# Altium schematic sheet load-cell.SchDoc
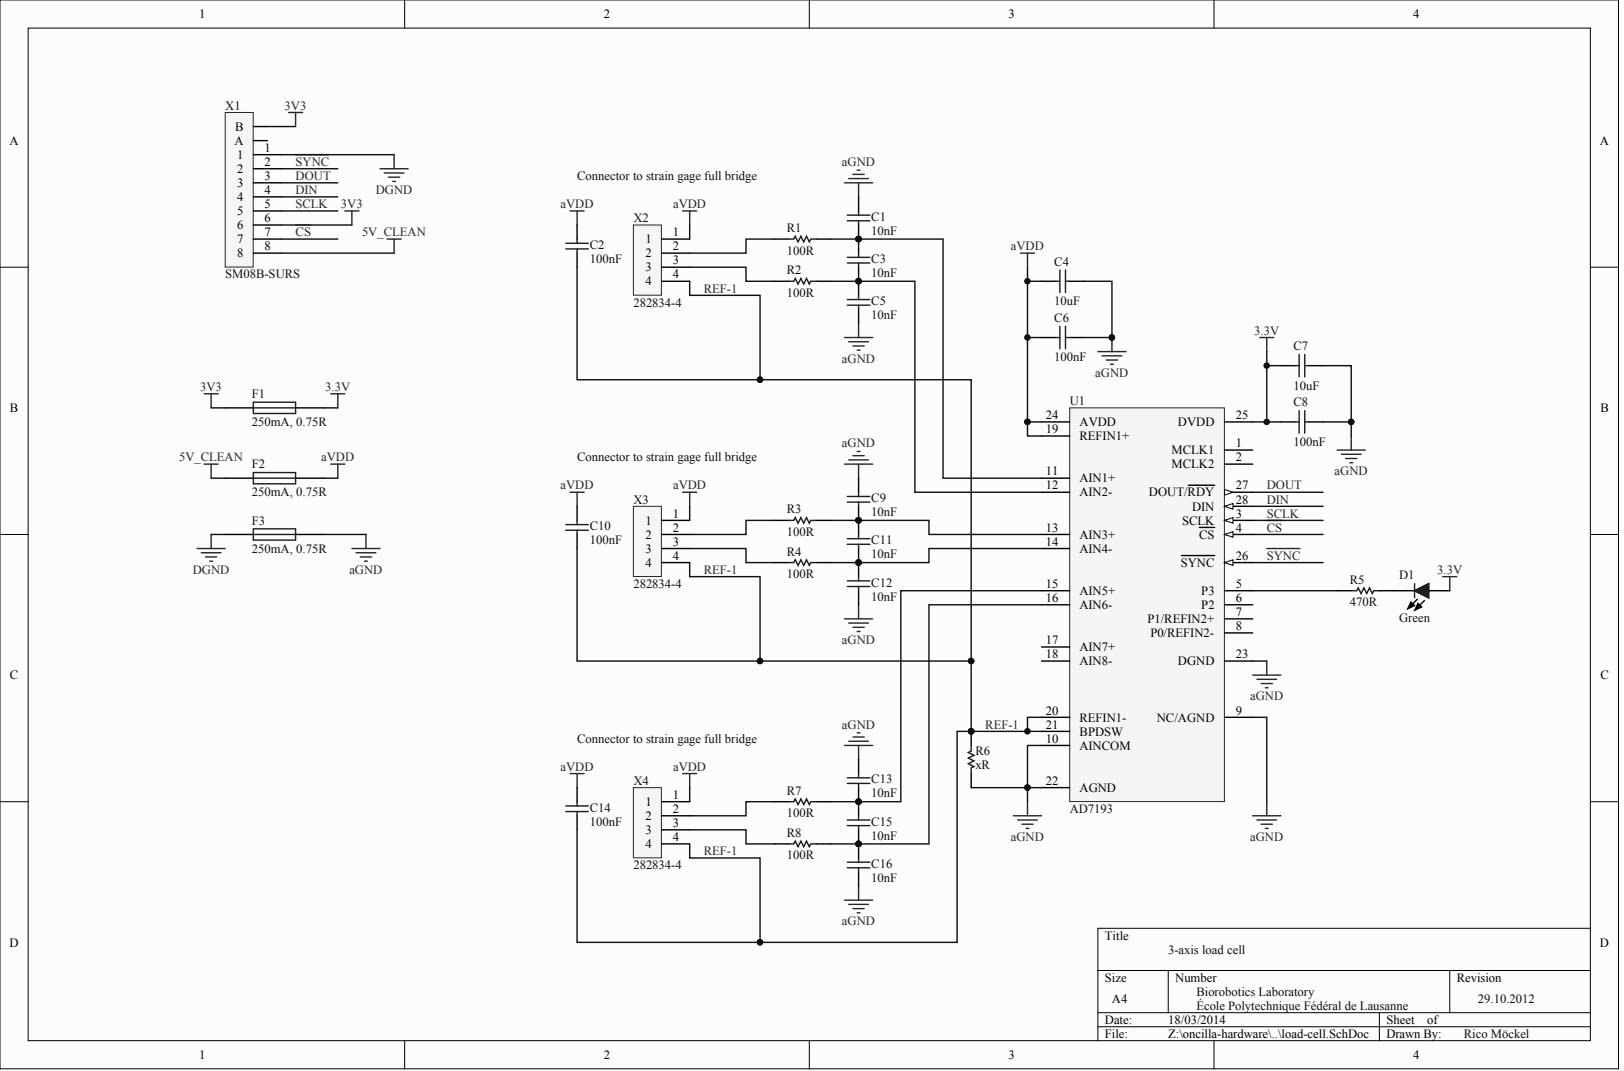
[diagram p0: load-cell.SchDoc]

## Parts
C1  10nF
C2  100nF
C3  10nF
C4  10uF
C5  10nF
C6  100nF
C7  10uF
C8  100nF
C9  10nF
C10  100nF
C11  10nF
C12  10nF
C13  10nF
C14  100nF
C15  10nF
C16  10nF
D1  Color: Green  (hidden comment: LED Green)
F1  250mA, 0.75R
F2  250mA, 0.75R
F3  250mA, 0.75R
R1  100R
R2  100R
R3  100R
R4  100R
R5  470R
R6  xR
R7  100R
R8  100R
U1  AD7193
X1  SM08B-SURS
X2  282834-4
X3  282834-4
X4  282834-4

## Nets
3.3V: C7.2 C8.2 D1.1 F1.2 U1.25(DVDD)
3V3: F1.1 X1.6 X1.B
5V_CLEAN: F2.1 X1.8
AGND: C1.1 C4.1 C5.2 C6.1 C7.1 C8.1 C9.1 C12.2 C13.1 C16.2 F3.2 R6.2 U1.9(NC/AGND) U1.10(AINCOM) U1.22(AGND) U1.23(DGND)
AVDD: C2.2 C4.2 C6.2 C10.2 C14.2 F2.2 U1.19(REFIN1+) U1.24(AVDD) X2.1 X3.1 X4.1
DGND: F3.1 X1.1
~{CS}: U1.4(CS) X1.7
DIN: U1.28(DIN) X1.4
DOUT: U1.27(DOUT/RDY) X1.3
REF-1: C2.1 C10.1 C14.1 R6.1 U1.20(REFIN1-) U1.21(BPDSW) X2.4 X3.4 X4.4
~{SYNC}: U1.26(SYNC) X1.2
SCLK: U1.3(SCLK) X1.5
NetC1_2: C1.2 C3.1 R1.2 U1.11(AIN1+)
NetC3_2: C3.2 C5.1 R2.2 U1.12(AIN2-)
NetC9_2: C9.2 C11.1 R3.2 U1.13(AIN3+)
NetC11_2: C11.2 C12.1 R4.2 U1.14(AIN4-)
NetC13_2: C13.2 C15.1 R7.2 U1.15(AIN5+)
NetC15_2: C15.2 C16.1 R8.2 U1.16(AIN6-)
NetD1_2: D1.2 R5.2
NetR1_1: R1.1 X2.2
NetR2_1: R2.1 X2.3
NetR3_1: R3.1 X3.2
NetR4_1: R4.1 X3.3
NetR5_1: R5.1 U1.5(P3)
NetR7_1: R7.1 X4.2
NetR8_1: R8.1 X4.3

Not connected: U1 1(MCLK1) 2(MCLK2) 6(P2) 7(P1/REFIN2+) 8(P0/REFIN2-) 17(AIN7+) 18(AIN8-); X1 A

## Library data of the parts
X2-X4 = (no symbol name): Manufacturer: TE CONNECTIVITY / BUCHANAN; Details: 4-pin connector with screw contacts, 2.54mm pitch; Name: 282834-4; Farnell: 1767502; Digikey: A98335-ND
C1-C16 D1 F1-F3 R1-R8 U1 X1 = (no symbol name)
  C1: Published: 8-Jun-2000; LatestRevisionDate: 17-Jul-2002; LatestRevisionNote: Re-released for DXP Platform.; PackageReference: RAD-0.3; Farnell: 1907314
  C2-C6 C8-C16: Published: 8-Jun-2000; LatestRevisionDate: 17-Jul-2002; LatestRevisionNote: Re-released for DXP Platform.; PackageReference: RAD-0.3
  C7: Published: 8-Jun-2000; LatestRevisionDate: 17-Jul-2002; LatestRevisionNote: Re-released for DXP Platform.; PackageReference: RAD-0.3; Farnell: 1962113
  F1-F3: Published: 8-Jun-2000; LatestRevisionDate: 17-Jul-2002; LatestRevisionNote: Re-released for DXP Platform.; PackageReference: PIN-W2/E2.8
  R1: Published: 8-Jun-2000; LatestRevisionDate: 17-Jul-2002; LatestRevisionNote: Re-released for DXP Platform.; PackageReference: AXIAL-0.3; Farnell: 1653251
  R2-R4 R6-R8: Published: 8-Jun-2000; LatestRevisionDate: 17-Jul-2002; LatestRevisionNote: Re-released for DXP Platform.; PackageReference: AXIAL-0.3
  R5: Published: 8-Jun-2000; LatestRevisionDate: 17-Jul-2002; LatestRevisionNote: Re-released for DXP Platform.; PackageReference: AXIAL-0.3; Farnell: 1738900
  C1-C16 F1-F3 R1-R8: Publisher: Altium Limited
